# Altium schematic sheet 3B0800_Main.SchDoc
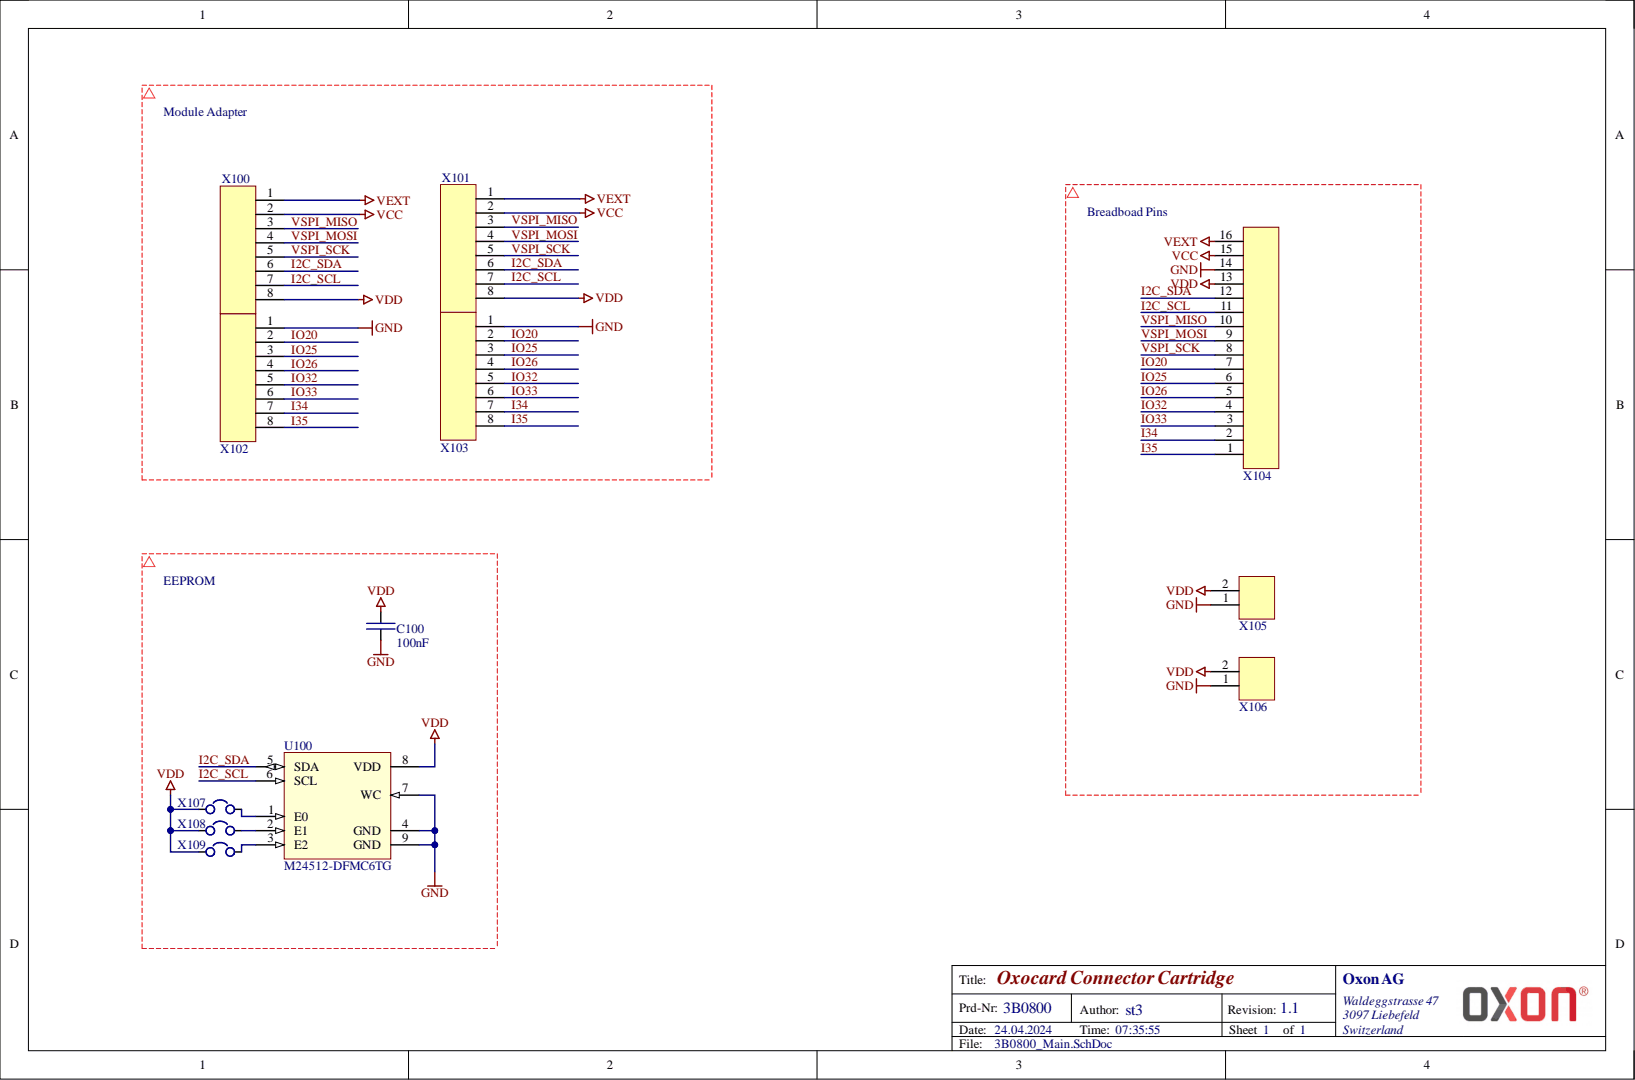
[diagram p0: 3B0800_Main.SchDoc]

## Parts
C100  100nF  [CAPC1005X60]
U100  M24512-DFMC6TG  [DFN8 2x3]
X100  Header BC-12-8P170-3U Pads  [X-HDR_BC-12-8P170-3U_Pads]  (value not drawn)
X101  Header BC-12-8P170-3U Pads  [X-HDR_BC-12-8P170-3U_Pads]  (value not drawn)
X102  Header BC-12-8P170-3U Pads  [X-HDR_BC-12-8P170-3U_Pads]  (value not drawn)
X103  Header BC-12-8P170-3U Pads  [X-HDR_BC-12-8P170-3U_Pads]  (value not drawn)
X104  X-HEADER-1X16  [X-HDR1X16]  (value not drawn)
X105  X-HEADER-1X2  [X-HDR1X2]  (value not drawn)
X106  X-HEADER-1X2  [X-HDR1X2]  (value not drawn)
X107  Solder Brodge OPEN  [X-SOLDER_BRIDGE_OPEN]  (value not drawn)
X108  Solder Brodge OPEN  [X-SOLDER_BRIDGE_OPEN]  (value not drawn)
X109  Solder Brodge OPEN  [X-SOLDER_BRIDGE_OPEN]  (value not drawn)

## Nets
GND: C100.1 U100.4(GND) U100.7(WC) U100.9(GND) X102.1 X103.1 X104.14 X105.1 X106.1
VCC: X100.2 X101.2 X104.15
VDD: C100.2 U100.8(VDD) X100.8 X101.8 X104.13 X105.2 X106.2 X107.1 X108.1 X109.1
VEXT: X100.1 X101.1 X104.16
I2C_SCL: U100.6(SCL) X100.7 X101.7 X104.11
I2C_SDA: U100.5(SDA) X100.6 X101.6 X104.12
I34: X102.7 X103.7 X104.2
I35: X102.8 X103.8 X104.1
IO20: X102.2 X103.2 X104.7
IO25: X102.3 X103.3 X104.6
IO26: X102.4 X103.4 X104.5
IO32: X102.5 X103.5 X104.4
IO33: X102.6 X103.6 X104.3
VSPI_MISO: X100.3 X101.3 X104.10
VSPI_MOSI: X100.4 X101.4 X104.9
VSPI_SCK: X100.5 X101.5 X104.8
NetU100_1: U100.1(E0) X107.2
NetU100_2: U100.2(E1) X108.2
NetU100_3: U100.3(E2) X109.2

## Library data of the parts
C100 = C-CAP0402_100n: SMD capacitor 10V 5%; Manufacturer: muRata; Manufacturer Designator: GRM155R61A104JA1D; FeederNo: 13; Head: 0; Mode: 1; Mount Speed(%): 100; Component Kind: Standard; Distributor: Mouser; Distributor Part-Number: 81-GRM155R61A104JA1D; Height: 0.5; Library Name: XLib.SCHLIB; Link: https://www.mouser.ch/ProductDetail/Murata-Electronics/GRM155R61A104JA01D?qs=IPQhFcwEUOgGKVF5CfrkAQ%3D%3D; Nozzle: 1; PnP: 1; Skip: 0
U100 = U-M24512-DFMC6TG: 512 kbit EEPROM; Manufacturer: STMicroelectronics; FeederNo: 0; Mode: 1; Mount Speed(%): 100; Component Kind: Standard; Distributor: Mouser; Distributor Part-Number: 511-M24512-DFMC6TG; Head: 0; Height: 0.55; Library Name: XLib.SCHLIB; Manufacturer Designator: M24512-DFMC6TG; Nozzle: 1; PnP: 0; Skip: 0
X100-X103 = X-HEADER-BC-12-8P170-3U_Pads: BC-12-8P170-3U Header Pads; FeederNo: 0; Mode: 1; Mount Speed(%): 100; Component Kind: Standard; Head: 0; Height: 0; Library Name: XLib.SCHLIB; Nozzle: 1; PnP: 0; Skip: 0
X104 = X-HEADER-1X16: Header 1x16; Manufacturer: Amphenol FCI; Manufacturer Designator: 10129378-916001BLF; FeederNo: 0; Mode: 1; Mount Speed(%): 100; Component Kind: Standard; Distributor: Mouser; Distributor Part-Number: 649-1012937891601BLF; Head: 0; Height: 0; Library Name: XLib.SCHLIB; Nozzle: 1; PnP: 0; Skip: 0
X105 X106 = X-HEADER-1X2: Header 1x2; FeederNo: 0; Mode: 1; Mount Speed(%): 100; Component Kind: Standard; Head: 0; Height: 0; Library Name: XLib.SCHLIB; Nozzle: 1; PnP: 0; Skip: 0
X107-X109 = X-Solder_Brodge_OPEN: FeederNo: 0; PnP: -1; Component Kind: Standard; Description: Solder Brodge OPEN; Library Name: XLib.SCHLIB
